# Altium schematic sheet SH02 - MCU & IOs.SchDoc
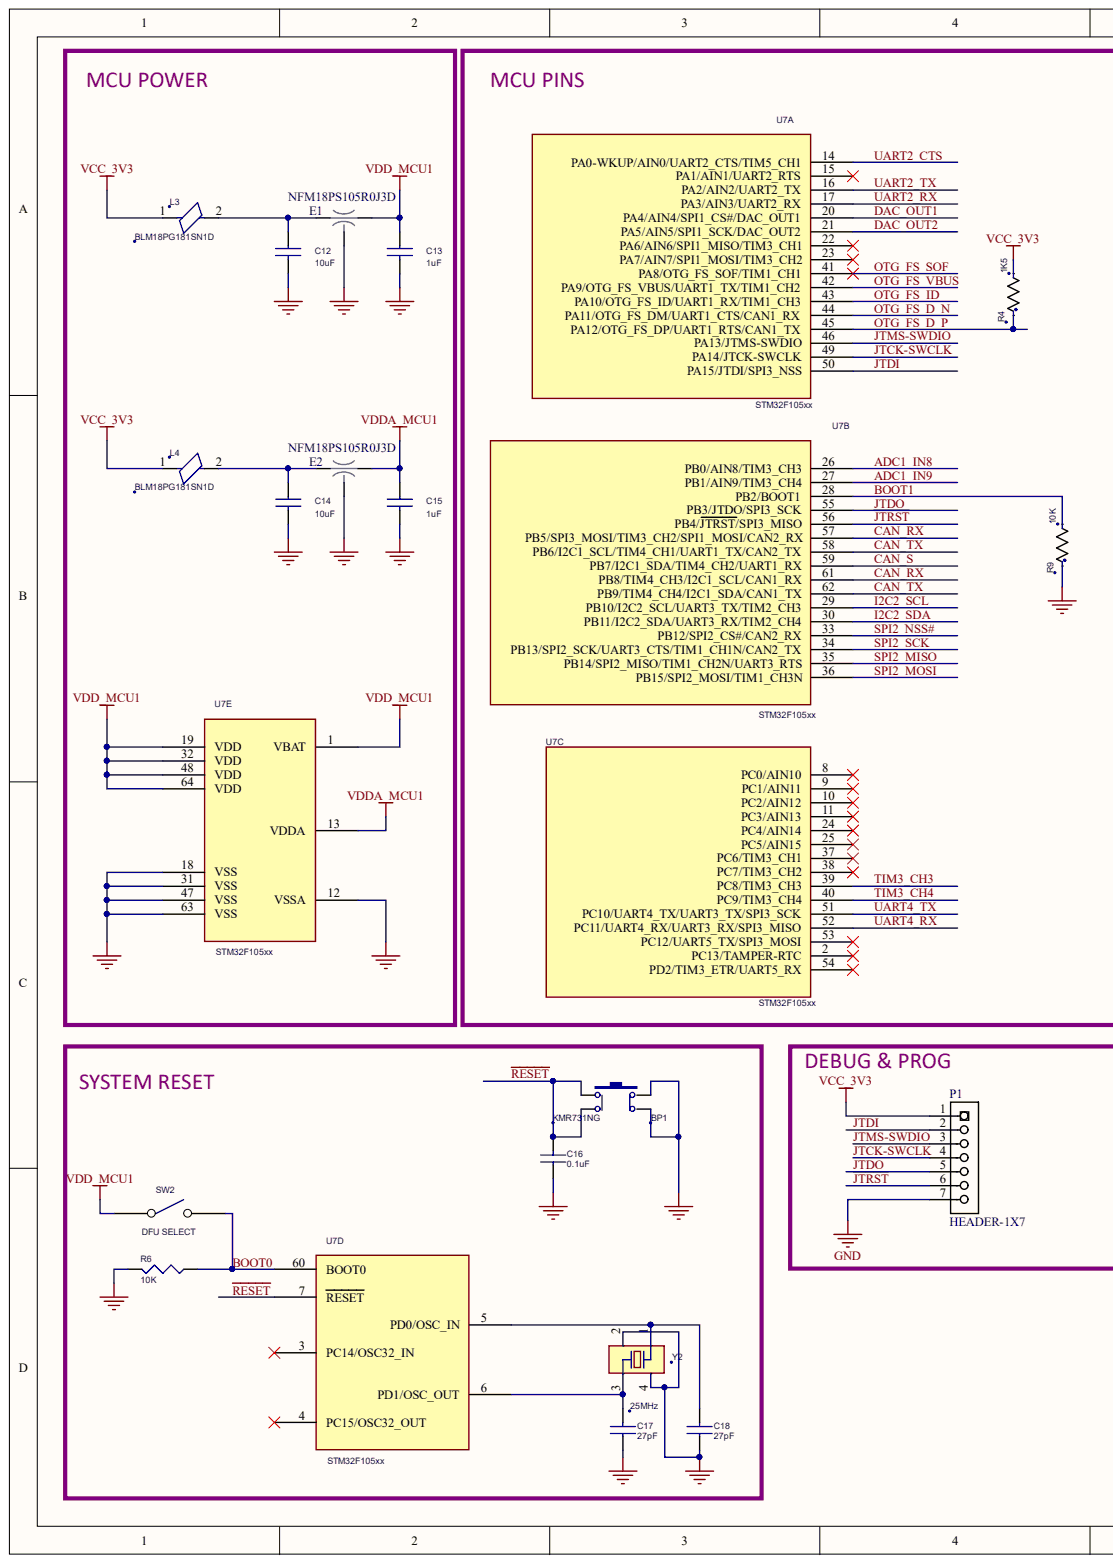
[diagram p0: SH02 - MCU & IOs.SchDoc, left]
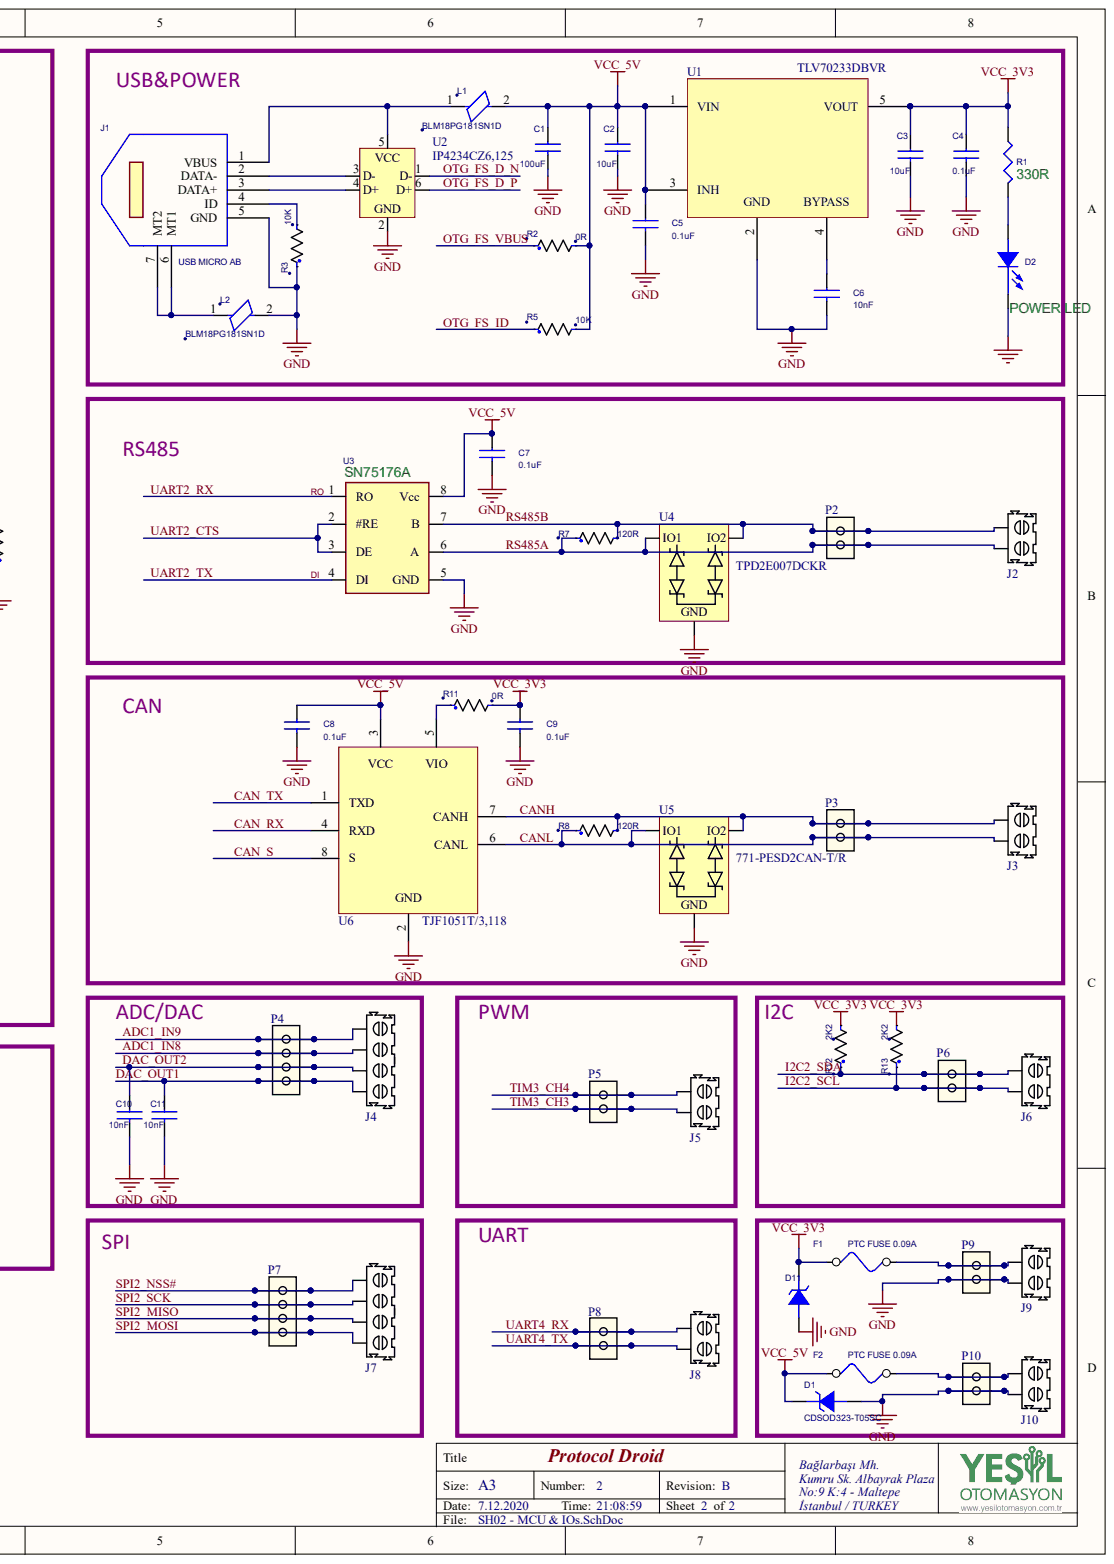
[diagram p1: SH02 - MCU & IOs.SchDoc, right]

## Parts
BP1  KMR731NG  [KMR7]
C1  100uF  [SM/C_1210]
C2  10uF  [RC0603]
C3  10uF  [RC0603]
C4  0.1uF  [RC0603]
C5  0.1uF  [RC0603]
C6  10nF  [RC0603]
C7  0.1uF  [RC0603]
C8  0.1uF  [RC0603]
C9  0.1uF  [RC0603]
C10  10nF  [RC0603]
C11  10nF  [RC0603]
C12  10uF  [RC0603]
C13  1uF  [RC0603]
C14  10uF  [RC0603]
C15  1uF  [RC0603]
C16  0.1uF  [RC0603]
C17  27pF  [RC0603]
C18  27pF  [RC0603]
D1  CDSOD323-T05SC  [SOD323]
D2  POWER LED  [SM/D_0805]
D11  SP4020-01FTG-C  [SOD323]  (value not drawn)
E1  NFM18PS105R0J3D  [SM/E_0603-3P]
E2  NFM18PS105R0J3D  [SM/E_0603-3P]
F1  PTC FUSE 0.09A  [SM/C_1210]
F2  PTC FUSE 0.09A  [SM/C_1210]
J1  USB MICRO AB  [USB/MICROAB/FCI_10104111]
J2  1984617  [OSTTE020161]  (value not drawn)
J3  1984617  [OSTTE020161]  (value not drawn)
J4  1984633  [OSTTE040161]  (value not drawn)
J5  1984617  [OSTTE020161]  (value not drawn)
J6  1984617  [OSTTE020161]  (value not drawn)
J7  1984633  [OSTTE040161]  (value not drawn)
J8  1984617  [OSTTE020161]  (value not drawn)
J9  1984617  [OSTTE020161]  (value not drawn)
J10  1984617  [OSTTE020161]  (value not drawn)
L1  BLM18PG181SN1D  [R0603]
L2  BLM18PG181SN1D  [R0603]
L3  BLM18PG181SN1D  [R0603]
L4  BLM18PG181SN1D  [R0603]
P1  HEADER-1X7  [HDR1X7]  (no BOM)
P2  861400021YO2LF  [HEADER_254_FEM_2x1]  (value not drawn)
P3  861400021YO2LF  [HEADER_254_FEM_2x1]  (value not drawn)
P4  861400041YO2LF  [HEADER_254_FEM_4x1]  (value not drawn)
P5  861400021YO2LF  [HEADER_254_FEM_2x1]  (value not drawn)
P6  861400021YO2LF  [HEADER_254_FEM_2x1]  (value not drawn)
P7  861400041YO2LF  [HEADER_254_FEM_4x1]  (value not drawn)
P8  861400021YO2LF  [HEADER_254_FEM_2x1]  (value not drawn)
P9  861400021YO2LF  [HEADER_254_FEM_2x1]  (value not drawn)
P10  861400021YO2LF  [HEADER_254_FEM_2x1]  (value not drawn)
R1  330R  [R0603]
R2  0R  [R0603]
R3  10K  [R0603]
R4  1K5  [R0603]
R5  10K  [R0603]
R6  10K  [R0603]
R7  120R  [R0603]
R8  120R  [R0603]
R9  10K  [R0603]
R11  0R  [R0603]
R12  2K2  [R0603]
R13  2K2  [R0603]
SW2  DFU SELECT  [HEADER_2_2.54MM]  (no BOM)
U1  TLV70233DBVR  [SOT-23-5]
U2  IP4234CZ6,125  [SOT457]
U3  SN75176A  [8-SOIC]
U4  TPD2E007DCKR  [R-PDSO-G3]
U5  771-PESD2CAN-T/R  [SOT-23]
U6  TJF1051T/3,118  [8-SOIC]
U7  STM32F105xx  [SM/LQFP64/0.5MM]  (parts A,B,C,D,E)
Y2  25MHz  [SM/XTAL_3.2x2.5]

## Nets
GND: BP1.2 BP1.4 C1.2 C2.2 C3.2 C4.2 C5.2 C6.2 C7.2 C8.2 C9.2 C10.2 C11.2 C12.2 C13.2 C14.2 C15.2 C16.1 C17.1 C18.1 D1.A D2.2 D11.A E1.3 E2.3 J1.5(GND) J9.2 J10.2 L2.2 P1.7 P9.2 P10.2 R3.1 R6.2 R9.1 U1.2 U2.2 U3.5(GND) U4.3 U5.3 U6.2 U7.12(VSSA) U7.18(VSS) U7.31(VSS) U7.47(VSS) U7.63(VSS) Y2.2 Y2.4
VCC_3V3: C3.1 C4.1 C9.1 D11.K F1.1 L3.1 L4.1 P1.1 R1.1 R4.2 R11.2 R12.2 R13.2 U1.5(VOUT)
VCC_5V: C1.1 C2.1 C5.1 C7.1 C8.1 D1.K F2.1 L1.2 R2.2 R5.2 U1.1(VIN) U1.3(INH) U3.8(Vcc) U6.3
VDD_MCU1: C13.1 E1.2 SW2.1 U7.1(VBAT) U7.19(VDD) U7.32(VDD) U7.48(VDD) U7.64(VDD)
VDDA_MCU1: C15.1 E2.2 U7.13(VDDA)
ADC1_IN8: J4.2 P4.2 U7.26(PB0/AIN8/TIM3_CH3)
ADC1_IN9: J4.1 P4.1 U7.27(PB1/AIN9/TIM3_CH4)
BOOT0: R6.1 SW2.2 U7.60(BOOT0)
BOOT1: R9.2 U7.28(PB2/BOOT1)
CAN_RX: U6.4(RXD) U7.57(PB5/SPI3_MOSI/TIM3_CH2/SPI1_MOSI/CAN2_RX) U7.61(PB8/TIM4_CH3/I2C1_SCL/CAN1_RX)
CAN_S: U6.8(S) U7.59(PB7/I2C1_SDA/TIM4_CH2/UART1_RX)
CAN_TX: U6.1(TXD) U7.58(PB6/I2C1_SCL/TIM4_CH1/UART1_TX/CAN2_TX) U7.62(PB9/TIM4_CH4/I2C1_SDA/CAN1_TX)
CANH: J3.1 P3.1 R8.2 U5.2 U6.7(CANH)
CANL: J3.2 P3.2 R8.1 U5.1 U6.6(CANL)
DAC_OUT1: C11.1 J4.4 P4.4 U7.20(PA4/AIN4/SPI1_CS#/DAC_OUT1)
DAC_OUT2: C10.1 J4.3 P4.3 U7.21(PA5/AIN5/SPI1_SCK/DAC_OUT2)
DI: U3.4(DI) U7.16(PA2/AIN2/UART2_TX)
I2C2_SCL: J6.2 P6.2 R13.1 U7.29(PB10/I2C2_SCL/UART3_TX/TIM2_CH3)
I2C2_SDA: J6.1 P6.1 R12.1 U7.30(PB11/I2C2_SDA/UART3_RX/TIM2_CH4)
~{JTRST}: P1.6 U7.56(PB4/JTRST/SPI3_MISO)
JTCK-SWCLK: P1.4 U7.49(PA14/JTCK-SWCLK)
JTDI: P1.2 U7.50(PA15/JTDI/SPI3_NSS)
JTDO: P1.5 U7.55(PB3/JTDO/SPI3_SCK)
JTMS-SWDIO: P1.3 U7.46(PA13/JTMS-SWDIO)
OTG_FS_D_N: U2.1(D-) U7.44(PA11/OTG_FS_DM/UART1_CTS/CAN1_RX)
OTG_FS_D_P: R4.1 U2.6(D+) U7.45(PA12/OTG_FS_DP/UART1_RTS/CAN1_TX)
OTG_FS_ID: R5.1 U7.43(PA10/OTG_FS_ID/UART1_RX/TIM1_CH3)
OTG_FS_SOF: U7.41(PA8/OTG_FS_SOF/TIM1_CH1)
OTG_FS_VBUS: R2.1 U7.42(PA9/OTG_FS_VBUS/UART1_TX/TIM1_CH2)
~{RESET}: BP1.1 BP1.3 C16.2 U7.7(RESET)
RO: U3.1(RO) U7.17(PA3/AIN3/UART2_RX)
RS485A: J2.2 P2.2 R7.1 U3.6(A) U4.1
RS485B: J2.1 P2.1 R7.2 U3.7(B) U4.2
SPI2_MISO: J7.3 P7.3 U7.35(PB14/SPI2_MISO/TIM1_CH2N/UART3_RTS)
SPI2_MOSI: J7.4 P7.4 U7.36(PB15/SPI2_MOSI/TIM1_CH3N)
SPI2_NSS#: J7.1 P7.1 U7.33(PB12/SPI2_CS#/CAN2_RX)
SPI2_SCK: J7.2 P7.2 U7.34(PB13/SPI2_SCK/UART3_CTS/TIM1_CH1N/CAN2_TX)
TIM3_CH3: J5.2 P5.2 U7.39(PC8/TIM3_CH3)
TIM3_CH4: J5.1 P5.1 U7.40(PC9/TIM3_CH4)
UART2_CTS: U3.2(#RE) U3.3(DE) U7.14(PA0-WKUP/AIN0/UART2_CTS/TIM5_CH1)
UART4_RX: J8.1 P8.1 U7.52(PC11/UART4_RX/UART3_RX/SPI3_MISO)
UART4_TX: J8.2 P8.2 U7.51(PC10/UART4_TX/UART3_TX/SPI3_SCK)
NetC6_1: C6.1 U1.4
NetC12_1: C12.1 E1.1 L3.2
NetC14_1: C14.1 E2.1 L4.2
NetC17_2: C17.2 U7.6(PD1/OSC_OUT) Y2.3
NetC18_2: C18.2 U7.5(PD0/OSC_IN) Y2.1
NetD2_1: D2.1 R1.2
NetF1_2: F1.2 J9.1 P9.1
NetF2_2: F2.2 J10.1 P10.1
NetJ1_1: J1.1(VBUS) L1.1 U2.5
NetJ1_2: J1.2(DATA-) U2.3(D-)
NetJ1_3: J1.3(DATA+) U2.4(D+)
NetJ1_4: J1.4(ID) R3.2
NetJ1_6: J1.6(MT1) J1.7(MT2) L2.1
NetR11_1: R11.1 U6.5

Not connected: U7 2(PC13/TAMPER-RTC) 3(PC14/OSC32_IN) 4(PC15/OSC32_OUT) 8(PC0/AIN10) 9(PC1/AIN11) 10(PC2/AIN12) 11(PC3/AIN13) 15(PA1/AIN1/UART2_RTS) 22(PA6/AIN6/SPI1_MISO/TIM3_CH1) 23(PA7/AIN7/SPI1_MOSI/TIM3_CH2) 24(PC4/AIN14) 25(PC5/AIN15) 37(PC6/TIM3_CH1) 38(PC7/TIM3_CH2) 53(PC12/UART5_TX/SPI3_MOSI) 54(PD2/TIM3_ETR/UART5_RX)

## Library data of the parts
BP1 = switch2_0: SWITCH TACTILE SPST-NO 50MA 32V; Manufacturer: ITT C&K; Manufacturer Part Number: KMR731NGLFS; Actuator Height off PCB, Vertical: 2.50mm; Actuator Orientation: Top Actuated; Actuator Type: Standard; Categories: Switches; Contact Rating @ Voltage: 0.05A @ 32VDC; Illumination: Non-Illuminated; Ingress Protection: IP67 - Dust Tight, Waterproof; Mechanical Life: 150,000 Cycles; Operating Force: 300gf; Outline: 4.20mm x 2.80mm; Switch Function: Off-Mom; Actuator Length, Right Angle: -; Actuator Material: -; Circuit: SPST-NO; Component Kind: Standard; ComponentLink1Description: https://www.ckswitches.com/media/1908/kmr7.pdf?src-supplier=Digi-Key; ComponentLink1URL: https://www.ckswitches.com/media/1908/kmr7.pdf?src-supplier=Digi-Key; ComponentLink2Description: http://www.farnell.com/datasheets/2578460.pdf?src-supplier=Farnell; ComponentLink2URL: http://www.farnell.com/datasheets/2578460.pdf?src-supplier=Farnell; Features: -; Illumination Type, Color: -; Illumination Voltage (Nominal): -; Mounting Type: Surface Mount; Operating Temperature: -40°C ~ 85°C; Packaging: Tape & Reel (TR); Part Status: Active; Pricing 1: 1=0.34, 10=0.333, 25=0.3208, 50=0.309, 100=0.2972, 250=0.2734, 500=0.2378, 1000=0.2021 (USD); Series: KMR7; Stock 1: 5807; Supplier 1: Digi-Key; Supplier Part Number 1: CKN10687CT-ND; Switch Travel: -; Termination Style: Gull Wing
C1 = CAP NP: CAP,CER SMT 1210 100uF 6V3 20% X7S; Manufacturer: Murata; Manufacturer Part Number: GRM32EC70J107ME15L; Capacitance: 100µF; COMPFIXED: NO; COMPGROUP: 0; COMPLOC: [814.000,-1923.367]; COMPLOCKED: YES; COMPSIDE: TOP; Unit Cost 1k: 0,39; Voltage - Rated: 6.3V; Applications: General Purpose; Category: Capacitors; COMPHEIGHT: 0; COMPKEY: NO; Component Kind: Standard; ComponentLink1Description: http://search.murata.co.jp/Ceramy/image/img/A01X/G101/ENG/GRM32EC70J107ME15-01.pdf?src-supplier=Digi-Key; ComponentLink1URL: http://search.murata.co.jp/Ceramy/image/img/A01X/G101/ENG/GRM32EC70J107ME15-01.pdf?src-supplier=Digi-Key; ComponentLink2Description: http://www.produktinfo.conrad.com/datenblaetter/1300000-1399999/001316735-da-01-en-MLCC_1210_100_0_UF_6_3V_X7S__20__1000ST.pdf?src-supplier=Conrad; ComponentLink2URL: http://www.produktinfo.conrad.com/datenblaetter/1300000-1399999/001316735-da-01-en-MLCC_1210_100_0_UF_6_3V_X7S__20__1000ST.pdf?src-supplier=Conrad; COMPROT: 0; DECOUPLER: NO; Family: Ceramic Capacitors; Features: Derating Recommended; Height - Seated (Max): -; Lead Spacing: -; Lead Style: -; MEMORY: NO; Mounting Type: Surface Mount, MLCC; Operating Temperature: -55°C ~ 125°C; Package / Case: 1210 (3225 Metric); Packaging: Tape & Reel (TR); Pricing 1: 1=0.79, 10=0.582, 50=0.4658, 100=0.3993, 500=0.3161 (USD); Ratings: -; Series: GRM; Size / Dimension: 0.126" L x 0.098" W (3.20mm x 2.50mm); Stock 1: 52391; Supplier 1: Digi-Key; Supplier Part Number 1: 490-10530-6-ND; Temperature Coefficient: X7S; Thickness (Max): 0.106" (2.70mm); Tolerance: ±20%
C2 C3 C12 C14 = CAP NP: CAP,CER SMT 0603 10uF 6V3 10% X5R; Manufacturer: Murata Electronics; Manufacturer Part Number: GRM188R60J106ME84J; Capacitance: 10µF; Catalog Drawings: GRM Series_0603_0.8; COMPFIXED: NO; COMPGROUP: 0; COMPHEIGHT: 0.025; COMPLOC: [54.600,29.800]; COMPROT: 270; COMPSIDE: BOT; Dynamic Catalog: GRM Series; Other Names: 490-7317-2; Tolerance: ±20%; Unit Cost 1k: 0,011; Unit PriceUSD: GRM; Voltage - Rated: 6.3V; Applications: General Purpose; Category: Capacitors; COMPKEY: NO; COMPLOCKED: NO; Component Kind: Standard; ComponentLink1Description: https://www.murata.com/~/media/webrenewal/support/library/catalog/products/capacitor/mlcc/c02e.ashx?la=en-us&src-supplier=Digi-Key; ComponentLink1URL: https://www.murata.com/~/media/webrenewal/support/library/catalog/products/capacitor/mlcc/c02e.ashx?la=en-us&src-supplier=Digi-Key; DECOUPLER: NO; Family: Ceramic Capacitors; MEMORY: NO; Minimum Quantity: 10µF; Mounting Type: Surface Mount, MLCC; Online Catalog: GRM Series; Operating Temperature: -55°C ~ 85°C; Package / Case: 0603 (1608 Metric); Package/Case: 0603 (1608 Metric); Packaging: Tape & Reel (TR); Pricing 1: 10000=0.0744, 20000=0.0721 (USD); Quantity Available: Tape & Reel (TR); Series: GRM; Size / Dimension: 0.063" L x 0.031" W (1.60mm x 0.80mm); Standard Package: 10,000; Stock 1: 30000; Supplier 1: Digi-Key; Temperature Coefficient: X5R; Thickness (Max): 0.035" (0.90mm)
C4 C5 C7-C9 C16 = CAP NP: CAP,CER SMT 0603 0.1uF 10V 10% X5R; Manufacturer Part Number: CC0603KRX7R6BB104; Brand: Yageo; COMPFIXED: NO; COMPGROUP: 0; COMPHEIGHT: 0.025; COMPLOC: [28.200,53.900]; COMPROT: 270; COMPSIDE: BOT; Dielectric: X7R; Type: Multilayer Ceramic Chip Capacitor; Unit Cost 1k: 0,00165; Unit Weight: 0.000071 oz; Voltage Rating DC: 10 VDC; Capacitance: 0.1 uF; Case Code - in: 0603; Case Code - mm: 1608; COMPKEY: NO; COMPLOCKED: NO; Component Kind: Standard; ComponentLink1Description: http://www.yageo.com/documents/recent/UPY-GPHC_X7R_6.3V-to-50V_8.pdf?src-supplier=Avnet; ComponentLink1URL: http://www.yageo.com/documents/recent/UPY-GPHC_X7R_6.3V-to-50V_8.pdf?src-supplier=Avnet; ComponentLink2Description: http://www.yageo.com/documents/recent/UPY-GPHC_X7R_6.3V-to-50V_8.pdf?src-supplier=Avnet+Europe; ComponentLink2URL: http://www.yageo.com/documents/recent/UPY-GPHC_X7R_6.3V-to-50V_8.pdf?src-supplier=Avnet+Europe; DECOUPLER: NO; Length: 1.6 mm; Manufacturer: Yageo; Maximum Operating Temperature: + 125 C; MEMORY: NO; Minimum Operating Temperature: - 55 C; Package / Case: 0603 (1608 metric); Packaging: Reel; Pricing 1: 1=0.12, 10=0.06, 100=0.027, 1000=0.022, 4000=0.016, 8000=0.016 (USD); Product: General Type MLCCs; Product Category: Multilayer Ceramic Capacitors MLCC - SMD/SMT; RoHS: True; Stock 1: 5586; Supplier 1: Mouser; Termination Style: SMD/SMT; Tolerance: 10 %; Width: 0.8 mm
C6 C10 C11 = CAP NP: CAP,CER SMT 0603 10nF 10V 10% X5R; Manufacturer Part Number: C0603C103K8RACTU; Part # Aliases: C0603C103K8RAC7867; Brand: Kemet; Capacitance: 0.01 uF; Capacitance - pF: 10000 pF; Case Height: 0.8 mm; Case Length: 1.6 mm; Class: Class 1; COMPFIXED: NO; COMPGROUP: 0; COMPHEIGHT: 0.025; COMPLOC: [28.200,53.900]; COMPROT: 270; COMPSIDE: BOT; Dielectric: X7R; Dissipation Factor DF: 5; Temperature Coefficient / Code: +/- 15 %; Type: SMD MLCC X7R 6.3 - 250 VDC Commerical Grade; Unit Cost 1k: 0,00165; Capacitance - nF: 10 nF; Case Code - in: 0603; Case Code - mm: 1608; Case Width: 0.8 mm; COMPKEY: NO; COMPLOCKED: NO; Component Kind: Standard; ComponentLink1Description: http://www.jameco.com/Jameco/Products/ProdDS/1564916.pdf?src-supplier=Jameco; ComponentLink1URL: http://www.jameco.com/Jameco/Products/ProdDS/1564916.pdf?src-supplier=Jameco; DECOUPLER: NO; Dimensions: 0.8 mm W x 1.6 mm L x 0.8 mm H; Factory Pack Quantity: 4000; Lead Spacing: 0.7 mm; Manufacturer: KEMET; Maximum Operating Temperature: + 125 C; MEMORY: NO; Minimum Operating Temperature: - 55 C; Operating Temperature Range: - 55 C to + 125 C; Package / Case: 0603 (1608 metric); Packaging: Reel; Pricing 1: 1=0.1, 10=0.068, 50=0.037, 100=0.0302, 500=0.0216, 1000=0.0169 (USD); Product: General Type MLCCs; Product Category: Multilayer Ceramic Capacitors MLCC - SMD/SMT; RoHS: Details; Series: C0603C; Stock 1: 33298; Supplier 1: Digi-Key; Termination Style: SMD/SMT; Tolerance: 10 %; Voltage Rating: 10 V; Voltage Rating DC: 10 V
C13 C15 = CAP NP: CAP,CER SMT 0603 1uF 10V 10% X5R; Manufacturer: Samsung; Manufacturer Part Number: CL10A105KP8NNNC; Capacitance: 1000000 pF; COMPFIXED: NO; COMPGROUP: 0; COMPHEIGHT: 0.025; COMPLOC: [53.300,14.900]; COMPROT: 90; COMPSIDE: BOT; Unit Cost 1k: 0,00245; Case Size/Dimension: 0603; COMPKEY: NO; COMPLOCKED: NO; Component Kind: Standard; ComponentLink1Description: https://www.verical.com/server-webapp/html/getDatasheet?partID=358616&src-supplier=Verical; ComponentLink1URL: https://www.verical.com/server-webapp/html/getDatasheet?partID=358616&src-supplier=Verical; ComponentLink2Description: http://www1.futureelectronics.com/doc/SAMSUNG%20PASSIVE/CL05B104KQ5NNNC.pdf?src-supplier=Future+Electronics; ComponentLink2URL: http://www1.futureelectronics.com/doc/SAMSUNG%20PASSIVE/CL05B104KQ5NNNC.pdf?src-supplier=Future+Electronics; DECOUPLER: NO; Dielectric: X5R; MEMORY: NO; Pricing 1: 1=0.1, 10=0.038, 50=0.0208, 100=0.017, 500=0.0121, 1000=0.0095 (USD); Rated Voltage: 10 V; Stock 1: 655615; Supplier 1: Digi-Key; Supplier Part Number 1: 1276-1182-6-ND; Tolerance (%): ±10 %
C17 C18 = CAP NP: CAP,CER SMT 0603 27pF 50V 10% X5R; Manufacturer: Yageo; Manufacturer Part Number: CC0603JRNPO9BN270; COMPFIXED: NO; COMPGROUP: 0; COMPHEIGHT: 0.025; COMPLOC: [28.200,53.900]; COMPROT: 270; COMPSIDE: BOT; Featured Product: Commodity Multilayer Ceramic Capacitors – CC Series; Online Catalog: CC Series; Other Names: 311-1063-6 311-1281-6 311-1281-6-ND; Product Training Modules: Multilayer Ceramic Capacitors; Temperature Coefficient: C0G, NP0; Tolerance: ±5%; Unit Cost 1k: 0,00165; Applications: General Purpose; Capacitance: 27pF; Category: Capacitors; COMPKEY: NO; COMPLOCKED: NO; Component Kind: Standard; ComponentLink1Description: http://www.yageo.com/documents/recent/UPY-GP_NP0_16V-to-50V_16.pdf?src-supplier=Digi-Key; ComponentLink1URL: http://www.yageo.com/documents/recent/UPY-GP_NP0_16V-to-50V_16.pdf?src-supplier=Digi-Key; ComponentLink2Description: http://www.yageo.com/documents/recent/UPY-GP_NP0_16V-to-50V_8.pdf?src-supplier=Avnet; ComponentLink2URL: http://www.yageo.com/documents/recent/UPY-GP_NP0_16V-to-50V_8.pdf?src-supplier=Avnet; ComponentLink3Description: http://www.farnell.com/datasheets/2213336.pdf?src-supplier=Element14; ComponentLink3URL: http://www.farnell.com/datasheets/2213336.pdf?src-supplier=Element14; ComponentLink4Description: https://www.verical.com/server-webapp/html/getDatasheet?partID=403529&src-supplier=Verical; ComponentLink4URL: https://www.verical.com/server-webapp/html/getDatasheet?partID=403529&src-supplier=Verical; ComponentLink5Description: http://www.farnell.com/datasheets/1443161.pdf?src-supplier=Element14; ComponentLink5URL: http://www.farnell.com/datasheets/1443161.pdf?src-supplier=Element14; ComponentLink6Description: http://www.yageo.com/documents/recent/UPY-GP_NP0_16V-to-50V_8.pdf?src-supplier=Avnet+Europe; ComponentLink6URL: http://www.yageo.com/documents/recent/UPY-GP_NP0_16V-to-50V_8.pdf?src-supplier=Avnet+Europe; DECOUPLER: NO; Family: Ceramic Capacitors; Features: -; Height - Seated (Max): -; Lead Spacing: -; Lead Style: -; MEMORY: NO; Mounting Type: Surface Mount, MLCC; Operating Temperature: -55°C ~ 125°C; Package / Case: 0603 (1608 Metric); Packaging: Digi-Reel®; Pricing 1: 4000=0.0079, 8000=0.0072, 12000=0.0069 (USD); Ratings: -; Series: CC; Size / Dimension: 0.063" L x 0.031" W (1.60mm x 0.80mm); Standard Package: 1; Stock 1: 120000; Supplier 1: Digi-Key; Supplier Part Number 1: 311-1063-2-ND; Thickness (Max): 0.035" (0.90mm); Voltage - Rated: 50V
D1 = DIODE ZENER: TVS, DIODE 5V 13.5V SOD323; Manufacturer: Bourns Inc.; Capacitance @ Frequency: 350pF @ 1MHz; Current - Peak Pulse (10/1000µs): 42A (8/20µs); Power - Peak Pulse: 500W; Power Line Protection: No; Type: Zener; Voltage - Breakdown (Min): 6V; Voltage - Clamping (Max) @ Ipp: 13.5V (Typ); Applications: General Purpose; Category: Circuit Protection; Component Kind: Standard; ComponentLink1Description: http://www.bourns.com/docs/Product-Datasheets/CDSOD323-TxxSC.pdf; ComponentLink1URL: http://www.bourns.com/docs/Product-Datasheets/CDSOD323-TxxSC.pdf; Manufacturer Part Number: CDSOD323-T05SC; Mounting Type: Surface Mount; Operating Temperature: -55°C ~ 150°C (TJ); Package / Case: SC-76, SOD-323; Packaging: Cut Tape (CT); Part Status: Active; Pricing 1: 1=0.72, 10=0.606, 25=0.53, 100=0.4542, 250=0.39368, 500=0.3331, 1000=0.2574 (USD); RoHS: RoHS Compliant; Stock 1: 103990; Supplier 1: Digi-Key; Supplier Device Package: SOD-323; Supplier Part Number 1: CDSOD323-T05SCT-ND; Voltage - Reverse Standoff (Typ): 5V
D2 = LED: LED,SMT 0805 GREEN CLEAR; Manufacturer: Osram Opto; Manufacturer Part Number: LGR971-KN-1; Chip Material: GaP; DELETED: Compliant; Dominant Wave Length: 570 nm; Emitting Color: Yellow Green; Forward Current: 25 mA; Forward Voltage: 2.5 V; High Brightness: No; Lens Type: Colorless Diffused; Luminous Flux: 0.1lm; Luminous Intensity: 45mcd; Main Category: Chip LED; Number of  Elements: 1; Peak Wavelength: 572 nm; Power Dissipation: 65 W; Product Depth (mm): 1.35 mm; Product Height (mm): 0.9 mm; Product Length (mm): 2.1 mm; Reverse Voltage: 12 V; Shape Type: Rectangular; Test Current (It): 20 mA; Type: Uni-Color; Viewing Angle: 160 deg; Component Kind: Standard; ComponentLink1Description: https://www.verical.com/server-webapp/html/getDatasheet?partID=233880&src-supplier=Verical; ComponentLink1URL: https://www.verical.com/server-webapp/html/getDatasheet?partID=233880&src-supplier=Verical; ComponentLink2Description: https://www.verical.com/server-webapp/html/getDatasheet?partID=462214&src-supplier=Verical; ComponentLink2URL: https://www.verical.com/server-webapp/html/getDatasheet?partID=462214&src-supplier=Verical; ComponentLink3Description: https://dammedia.osram.info/media/resource/hires/osram-dam-2493936/LG%20R971.pdf?src-supplier=Digi-Key; ComponentLink3URL: https://dammedia.osram.info/media/resource/hires/osram-dam-2493936/LG%20R971.pdf?src-supplier=Digi-Key; ComponentLink4Description: http://www.mouser.com/ds/2/311/LG%20R971%20-%20CHIPLED%200805-318376.pdf?src-supplier=Mouser; ComponentLink4URL: http://www.mouser.com/ds/2/311/LG%20R971%20-%20CHIPLED%200805-318376.pdf?src-supplier=Mouser; Lens Dimensions: 1.4x1.35x0.5 mm; Lum Intensity: 45mcd; Mounting: Surface Mount; Operating Temperature Classification: Commercial; Operating Temp Range: -30C to 85C; Package Type: SMT; Packaging: Tape and Reel; Pin Count: 2; Pricing 1: 1=0.25, 10=0.186, 100=0.0882, 500=0.0588, 1000=0.046 (USD); Rad Hardened: No; Stock 1: 241820; Supplier 1: Digi-Key; Supplier Part Number 1: 475-1410-1-ND
D11 = DIODE ZENER: TVS,Diode Arrays 2.5pF 30A; Brand: Littelfuse; Breakdown Voltage: 3.5 V; Clamping Voltage: 21.8 V; Pd - Power Dissipation: 750 W; Polarity: Bidirectional; Working Voltage: 3.3 V; Component Kind: Standard; Factory Pack Quantity: 3000; Height: 1 mm; Length: 2.7 mm; Manufacturer: Littelfuse; Manufacturer Part Number: SP4020-01FTG-C; Maximum Operating Temperature: + 125 C; Minimum Operating Temperature: - 40 C; Mounting Style: SMD/SMT; Package / Case: SOD-323; Packaging: Reel; Peak Surge Current: 30 A; Pricing 1: 1=0.72, 10=0.606, 25=0.53, 100=0.4542, 250=0.3937, 500=0.3331, 1000=0.2574 (USD); Product Category: TVS Diode Arrays; RoHS: Details; Series: SP4020; Stock 1: 156787; Supplier 1: Digi-Key; Termination Style: SMD/SMT; Tradename: SPA; Width: 1.4 mm
E1 E2 = SM/0603-3P: CAP,FEEDTHRU 1UF 20% 6.3V 0603; Manufacturer: Murata Electronics; DC Resistance (DCR) (Max): 30 mOhm; Category: Filters; Component Kind: Standard; ComponentLink1Description: http://search.murata.co.jp/Ceramy/image/img/A01X/G101/ENG/NFM18PS105R0J3-01.pdf; ComponentLink1URL: http://search.murata.co.jp/Ceramy/image/img/A01X/G101/ENG/NFM18PS105R0J3-01.pdf; Height (Max): 0.028" (0.70mm); Insertion Loss: -; Manufacturer Part Number: NFM18PS105R0J3D; Mounting Type: Surface Mount; Operating Temperature: -55°C ~ 105°C; Package / Case: 0603 (1608 Metric), 3 PC Pad; Packaging: Cut Tape (CT); Part Status: Active; Pricing 1: 1=0.16, 10=0.152, 25=0.1448, 50=0.1402, 100=0.1356, 250=0.12856, 500=0.10752, 1000=0.08345 (USD); Ratings: -; RoHS: RoHS Compliant; Size / Dimension: 0.063" L x 0.032" W (1.60mm x 0.80mm); Stock 1: 444667; Supplier 1: Digi-Key; Supplier Part Number 1: 490-6974-1-ND; Temperature Coefficient: -; Thread Size: -; Tolerance: ±20%; Voltage - Rated: 6.3V; Library Name: SSTE.SCHLIB
F1 F2 = FUSE: PTC RESET FUSE 30V 90MA 1210; Manufacturer: EPCOS (TDK); Manufacturer Part Number: B59606A0110A062; Approvals: UR; Type: Ceramic; Category: Circuit Protection; Component Kind: Standard; ComponentLink1Description: https://en.tdk.eu/inf/55/db/PTC/PTC_OC_SMD_0402_0603_1210_24V_230V.pdf; ComponentLink1URL: https://en.tdk.eu/inf/55/db/PTC/PTC_OC_SMD_0402_0603_1210_24V_230V.pdf; Height - Seated (Max): -; Lead Spacing: -; Mounting Type: Surface Mount; Operating Temperature: -20°C ~ 85°C; Package / Case: 1210 (3225 Metric); Packaging: Tape & Reel (TR); Part Status: Active; Pricing 1: 2000=0.46682, 4000=0.45833, 6000=0.44135, 10000=0.42438 (USD); Ratings: AEC-Q200; RoHS: RoHS Compliant; Size / Dimension: 0.126" L x 0.098" W (3.20mm x 2.50mm); Stock 1: 6000; Supplier 1: Digi-Key; Supplier Part Number 1: 495-2416-2-ND; Thickness (Max): 0.071" (1.80mm); Voltage - Max: 30V
J1 = USB MICRO AB_0: CONN,SMD USB MICRO AB RECEPTACLE; Manufacturer: Amphenol FCI; Manufacturer Part Number: 10104111-0001LF; Unit Cost 1k: 0,37; Component Kind: Standard
J2 J3 J5 J6 J8-J10 = OSTTE020161: TERM,BLK 2POS SIDE ENT 3.5MM PCB; Manufacturer: Phoenix Contact; Actuation direction: Perpendicular (to the PCB); AWG (min.): 26; AWG max.: 16; Connection: Screw terminal, Print; Connector orientation: Parallel (to the PCB); Content: 250 pc(s); Cross section (flexible) (max.): 1.50 mm²; Cross section (flexible) (min.): 0.20 mm²; CS: 3.50 mm; Industrial packaging: Yes; Nominal current: 17.5 A; Nominal voltage: 200 V; Pins per row: 2; Stripping length (max.): 5.00 mm; Category: Screw terminal; Colour: Green; Component Kind: Standard; ComponentLink1Description: http://components.arrow.com/part/dataview/43944892S4798812N1555?src-supplier=Arrow+Electronics; ComponentLink1URL: http://components.arrow.com/part/dataview/43944892S4798812N1555?src-supplier=Arrow+Electronics; ComponentLink2Description: https://media.digikey.com/pdf/Data%20Sheets/Phoenix%20Contact%20PDFs/Combicon%20Compact%20Series.pdf?src-supplier=Digi-Key; ComponentLink2URL: https://media.digikey.com/pdf/Data%20Sheets/Phoenix%20Contact%20PDFs/Combicon%20Compact%20Series.pdf?src-supplier=Digi-Key; ComponentLink3Description: https://www.verical.com/server-webapp/html/getDatasheet?partID=212214&src-supplier=Verical; ComponentLink3URL: https://www.verical.com/server-webapp/html/getDatasheet?partID=212214&src-supplier=Verical; Contact material: Tin plated; Cross section (inflexible) (max.): 1.50 mm²; Cross section (inflexible) (min.): 0.20 mm²; Features: + wire protector; Height: 7.60 mm; Length: 7.00 mm; Manufacturer part #: 1984617; Manufacturer Part Number: 1984617; Number of pins: 2; Pricing 1: 1=0.48, 10=0.459, 50=0.439, 100=0.4269, 250=0.399, 500=0.3671, 1000=0.3392, 2500=0.3391 (USD); Series: PT; Stock 1: 10306; Supplier 1: Digi-Key; Type: PT 1,5/ 2-3,5-H; Width: 13.70 mm; Wire protection: Yes; Library Name: SSTE.SCHLIB
J4 J7 = OSTTE040161: TERM,BLK 4POS SIDE ENT 3.5MM PCB; Manufacturer: Phoenix Contact; Actuation direction: Perpendicular (to the PCB); AWG (min.): 26; AWG max.: 16; Connection: Screw terminal, Print; Connector orientation: Parallel (to the PCB); Content: 250 pc(s); Cross section (flexible) (max.): 1.50 mm²; Cross section (flexible) (min.): 0.20 mm²; Cross section (inflexible) (min.): 0.14 mm²; CS: 3.50 mm; Industrial packaging: Yes; Nominal current: 17.5 A; Nominal voltage: 200 V; Pins per row: 4; Stripping length (max.): 5.00 mm; Category: Screw terminal; Colour: Green; Component Kind: Standard; ComponentLink1Description: https://media.digikey.com/pdf/Data%20Sheets/Phoenix%20Contact%20PDFs/Combicon%20Compact%20Series.pdf?src-supplier=Digi-Key; ComponentLink1URL: https://media.digikey.com/pdf/Data%20Sheets/Phoenix%20Contact%20PDFs/Combicon%20Compact%20Series.pdf?src-supplier=Digi-Key; Contact material: Tin plated; Cross section (inflexible) (max.): 1.50 mm²; Features: + wire protector; Height: 13.70 mm; Length: 7.60 mm; Manufacturer part #: 1984633; Manufacturer Part Number: 1984633; Number of pins: 4; Pricing 1: 1=0.95, 10=0.906, 50=0.8662, 100=0.8426, 250=0.7875, 500=0.7245, 1000=0.6694 (USD); Series: PT; Stock 1: 8573; Supplier 1: Digi-Key; Supplier Part Number 1: 277-1860-ND; Type: PT 1,5/ 4-3,5-H; Width: 14.00 mm; Wire protection: Yes; Library Name: SSTE.SCHLIB
L1-L4 = BEAD_ FERRITE: FERRITE BEAD, 180 OHM@100 MHZ 0603 1LN; Manufacturer: Murata; Component Kind: Standard; DESIG: Ferrite Bead; Manufacturer Part Number: BLM18PG181SN1D
P2 P3 P5 P6 P8-P10 = 1x2 Header Female 2.54: CONN,HEADER MALE 2POS .1 SGL TIN; Manufacturer: Amphenol FCI; Brand: FCI; Current Rating: 3 A; Insulation Resistance: 1000 MOhms; Mounting Angle: Straight; Number of Positions: 2; Pitch: 2.54 mm; Voltage Rating: 100 V; Component Kind: Standard; ComponentLink1Description: http://portal.fciconnect.com/Comergent/fci/drawing/c-8614-0089.pdf?src-supplier=Avnet; ComponentLink1URL: http://portal.fciconnect.com/Comergent/fci/drawing/c-8614-0089.pdf?src-supplier=Avnet; ComponentLink2Description: http://www.fciconnect.com/fciredir.htm?partnum=861400021YO2LF&src-supplier=Mouser; ComponentLink2URL: http://www.fciconnect.com/fciredir.htm?partnum=861400021YO2LF&src-supplier=Mouser; Contact Gender: Pin (Male); Contact Material: Copper Alloy; Contact Plating: Gold; Factory Pack Quantity: 2000; Flammability Rating: UL 94; Housing Material: Thermoplastic; Manufacturer Part Number: 861400021YO2LF; Number of Rows: 1; Operating Temperature Range: - 55 C to + 125 C; Packaging: Bulk; Pricing 1: 8000=0.0448 (USD); Product Category: Headers & Wire Housings; Product Type: Headers; RoHS: Details; Series: 8614; Stock 1: 0; Supplier 1: Digi-Key; Termination Post Length: 3mm; Termination Style: Through Hole; Type: Unshrouded; Library Name: SSTE.SCHLIB
P4 P7 = 1x4 Header Female 2.54: CONN,HEADER MALE 4POS .1 SGL TIN; Manufacturer: Amphenol FCI; Brand: FCI; Component Kind: Standard; ComponentLink1Description: http://portal.fciconnect.com/Comergent/fci/drawing/c-8614-0089.pdf?src-supplier=Avnet; ComponentLink1URL: http://portal.fciconnect.com/Comergent/fci/drawing/c-8614-0089.pdf?src-supplier=Avnet; ComponentLink2Description: http://portal.fciconnect.com/Comergent//fci/drawing/c-8614-0089.pdf?src-supplier=Digi-Key; ComponentLink2URL: http://portal.fciconnect.com/Comergent//fci/drawing/c-8614-0089.pdf?src-supplier=Digi-Key; Factory Pack Quantity: 2000; Manufacturer Part Number: 861400041YO2LF; Packaging: Bulk; Pricing 1: 8000=0.0773 (USD); Product Category: Headers & Wire Housings; RoHS: Details; Series: BergStik; Stock 1: 0; Supplier 1: Digi-Key; Supplier Part Number 1: 861400041YO2LF-ND; Library Name: SSTE.SCHLIB
R1 = R_Dup1: RES,SMT 0603 330R 1/10WATT; Manufacturer Part Number: ERJ3EKF3300V; Brand: Panasonic; COMPFIXED: NO; COMPGROUP: 0; COMPLOC: [2004.000,-2464.383]; COMPLOCKED: YES; COMPSIDE: TOP; Power Rating: 100 mW; Qualification: AEC-Q200; Resistance: 330 Ohms; Temperature Coefficient: 100 PPM / C; Tolerance: 1 %; Unit Cost 1k: 0,00052; Unit Weight: 0.000071 oz; Voltage Rating: 75 V; Case Code - in: 0603; Case Code - mm: 1608; COMPHEIGHT: 0; COMPKEY: NO; Component Kind: Standard; ComponentLink1Description: http://www.farnell.com/datasheets/1911175.pdf?src-supplier=Element14; ComponentLink1URL: http://www.farnell.com/datasheets/1911175.pdf?src-supplier=Element14; ComponentLink2Description: https://industrial.panasonic.com/cdbs/www-data/pdf/RDA0000/DMA0000COL11.pdf?src-supplier=Avnet; ComponentLink2URL: https://industrial.panasonic.com/cdbs/www-data/pdf/RDA0000/DMA0000COL11.pdf?src-supplier=Avnet; ComponentLink3Description: http://www.farnell.com/datasheets/426256.pdf?src-supplier=Element14; ComponentLink3URL: http://www.farnell.com/datasheets/426256.pdf?src-supplier=Element14; ComponentLink4Description: http://industrial.panasonic.com/cdbs/www-data/pdf/RDA0000/AOA0000C304.pdf?src-supplier=Element14; ComponentLink4URL: http://industrial.panasonic.com/cdbs/www-data/pdf/RDA0000/AOA0000C304.pdf?src-supplier=Element14; COMPROT: 0; DECOUPLER: NO; Factory Pack Quantity: 5000; Height: 0.45 mm; Length: 1.6 mm; Manufacturer: Panasonic; MEMORY: NO; Operating Temperature Range: - 55 C to + 155 C; Package / Case: 0603 (1608 metric); Packaging: Reel; Pricing 1: 5000=0.005, 5000=0.04 (EUR); Product: Thick Film Resistors SMD; Product Category: Thick Film Resistors; RoHS: True; Series: ERJ; Stock 1: 300000; Supplier 1: RSComponents; Supplier Part Number 1: 1229278; Termination Style: SMD/SMT; Type: Precision Thick Film Resistors SMD; Width: 0.8 mm
R2 R11 = R_Generic_0: RES,SMT 0603 0R 1/10WATT 5%; Manufacturer: Yageo; Manufacturer Part Number: RC0603JR-070RL; DESIG: Thick film Resistor; Power Rating: 100mW; Product Range: RC Series; Resistance: 0ohm; Resistor Case Style: 0603 [1608 Metric]; Resistor Element Type: Thick Film; SVHC: To Be Advised; Temperature Coefficient: ± 200ppm/°C; Voltage Rating: 50V; Automotive Qualification Standard: -; Component Kind: Standard; ComponentLink1Description: http://www.farnell.com/datasheets/2167052.pdf?src-supplier=Element14; ComponentLink1URL: http://www.farnell.com/datasheets/2167052.pdf?src-supplier=Element14; ComponentLink2Description: https://www.verical.com/server-webapp/html/getDatasheet?partID=329462&src-supplier=Verical; ComponentLink2URL: https://www.verical.com/server-webapp/html/getDatasheet?partID=329462&src-supplier=Verical; ComponentLink3Description: http://www.yageo.com/documents/recent/PYu-RC_Group_51_RoHS_L_9.pdf?src-supplier=Mouser; ComponentLink3URL: http://www.yageo.com/documents/recent/PYu-RC_Group_51_RoHS_L_9.pdf?src-supplier=Mouser; ComponentLink4Description: http://www.farnell.com/datasheets/443971.pdf?src-supplier=Element14; ComponentLink4URL: http://www.farnell.com/datasheets/443971.pdf?src-supplier=Element14; ComponentLink5Description: http://documents.rs-components.com/techlib://itc_doclist_GB.htm?stockno=6515918&src-supplier=RSComponents; ComponentLink5URL: http://documents.rs-components.com/techlib://itc_doclist_GB.htm?stockno=6515918&src-supplier=RSComponents; MSL: MSL 1 - Unlimited; Packaging: Cut Tape; Pricing 1: 5000=0.0023, 10000=0.002, 25000=0.0017, 50000=0.0016 (USD); Resistance Tolerance: ± 5%; Stock 1: 14198877; Supplier 1: Digi-Key; Supplier Part Number 1: 311-0.0GRTR-ND
R3 R5 R9 = R_Generic_0: RES,SMT 0603 10K 1/10WATT 5%; Manufacturer: Yageo; Manufacturer Part Number: RC0603JR-0710KL; DESIG: Thick film Resistor; Power (Watts): 0.1W, 1/10W; Resistance: 10 kOhms; Temperature Coefficient: ±100ppm/°C; Component Kind: Standard; ComponentLink1Description: http://www.yageo.com/documents/recent/PYu-RC_Group_51_RoHS_L_10.pdf?src-supplier=Digi-Key; ComponentLink1URL: http://www.yageo.com/documents/recent/PYu-RC_Group_51_RoHS_L_10.pdf?src-supplier=Digi-Key; Composition: Thick Film; Features: Moisture Resistant; Height - Seated (Max): 0.022" (0.55mm); Number of Terminations: 2; Operating Temperature: -55°C ~ 155°C; Package / Case: 0603 (1608 Metric); Part Status: Active; Pricing 1: 1=0.1, 10=0.017, 100=0.007, 1000=0.0032, 2500=0.0027 (USD); Size / Dimension: 0.063" L x 0.031" W (1.60mm x 0.80mm); Stock 1: 300615; Supplier 1: Digi-Key; Supplier Device Package: 603; Supplier Part Number 1: 311-10KGRCT-ND; Tolerance: ±5%
R4 = R_Generic_0: RES,SMT 0603 1K5 1/10WATT 5%; Manufacturer: Yageo; Manufacturer Part Number: RC0603FR-071K5L; DESIG: Thick film Resistor; Power Rating: 100 mW; Resistance: 1.5 kOhms; Temperature Coefficient: 100 PPM / C; Tolerance: 1 %; Voltage Rating: 50 V; Case Code - in: 0603; Case Code - mm: 1608; Component Kind: Standard; ComponentLink1Description: http://www.yageo.com/documents/recent/PYu-RC_Group_51_RoHS_L_10.pdf?src-supplier=Digi-Key; ComponentLink1URL: http://www.yageo.com/documents/recent/PYu-RC_Group_51_RoHS_L_10.pdf?src-supplier=Digi-Key; ComponentLink2Description: http://www.farnell.com/datasheets/2167052.pdf?src-supplier=Element14; ComponentLink2URL: http://www.farnell.com/datasheets/2167052.pdf?src-supplier=Element14; Operating Temperature Range: - 55 C to + 155 C; Packaging: Reel; Pricing 1: 1=0.1, 10=0.021, 100=0.0085, 1000=0.0038, 2500=0.0033 (USD); Product: Thick Film Resistors General Purpose; Series: RC; Stock 1: 2665573; Supplier 1: Digi-Key; Supplier Part Number 1: 311-1.50KHRCT-ND; Termination Style: SMD/SMT
R6 = R: RES,SMT 0603 10K 1/10WATT 5%; Manufacturer: Yageo; Manufacturer Part Number: RC0603JR-0710KL; COMPFIXED: NO; COMPGROUP: 0; COMPLOC: [1210.333,-1518.367]; COMPLOCKED: YES; COMPROT: 180; COMPSIDE: TOP; Power (Watts): 0.1W, 1/10W; Resistance: 10 kOhms; Temperature Coefficient: ±100ppm/°C; Unit Cost 1k: 0,00033; COMPHEIGHT: 0; COMPKEY: NO; Component Kind: Standard; ComponentLink1Description: http://www.yageo.com/documents/recent/PYu-RC_Group_51_RoHS_L_10.pdf?src-supplier=Digi-Key; ComponentLink1URL: http://www.yageo.com/documents/recent/PYu-RC_Group_51_RoHS_L_10.pdf?src-supplier=Digi-Key; Composition: Thick Film; DECOUPLER: NO; Features: Moisture Resistant; Height - Seated (Max): 0.022" (0.55mm); MEMORY: NO; Number of Terminations: 2; Operating Temperature: -55°C ~ 155°C; Package / Case: 0603 (1608 Metric); Part Status: Active; Pricing 1: 1=0.1, 10=0.017, 100=0.007, 1000=0.0032, 2500=0.0027 (USD); Size / Dimension: 0.063" L x 0.031" W (1.60mm x 0.80mm); Stock 1: 300615; Supplier 1: Digi-Key; Supplier Device Package: 603; Supplier Part Number 1: 311-10KGRCT-ND; Tolerance: ±5%
R7 R8 = R_Generic_0: RES,SMT 0603 120R 1/10WATT 5%; Manufacturer: Yageo; Manufacturer Part Number: RC0603FR-07120RL; DESIG: Thick film Resistor; Power Rating: 100 mW; Resistance: 120 Ohms; Temperature Coefficient: 100 PPM / C; Tolerance: 1 %; Voltage Rating: 50 V; Case Code - in: 0603; Case Code - mm: 1608; Component Kind: Standard; ComponentLink1Description: http://www.yageo.com/documents/recent/PYu-RC_Group_51_RoHS_L_10.pdf?src-supplier=Digi-Key; ComponentLink1URL: http://www.yageo.com/documents/recent/PYu-RC_Group_51_RoHS_L_10.pdf?src-supplier=Digi-Key; ComponentLink2Description: http://www.farnell.com/datasheets/2167052.pdf?src-supplier=Element14; ComponentLink2URL: http://www.farnell.com/datasheets/2167052.pdf?src-supplier=Element14; ComponentLink3Description: http://www.farnell.com/datasheets/1868787.pdf?src-supplier=Element14; ComponentLink3URL: http://www.farnell.com/datasheets/1868787.pdf?src-supplier=Element14; ComponentLink4Description: http://www.farnell.com/datasheets/443971.pdf?src-supplier=Element14; ComponentLink4URL: http://www.farnell.com/datasheets/443971.pdf?src-supplier=Element14; Operating Temperature Range: - 55 C to + 155 C; Packaging: Reel; Pricing 1: 1=0.1, 10=0.021, 100=0.0085, 1000=0.0038, 2500=0.0033 (USD); Product: Thick Film Resistors General Purpose; Series: RC; Stock 1: 155053; Supplier 1: Digi-Key; Supplier Part Number 1: 311-120HRCT-ND; Termination Style: SMD/SMT
R12 R13 = R_Generic_0: RES,SMT 0603 2K2 1/10WATT 5%; Manufacturer: Yageo; Manufacturer Part Number: RC0603FR-072K2L; DESIG: Thick film Resistor; Power Rating: 100 mW; Resistance: 2.2 kOhms; Temperature Coefficient: 100 PPM / C; Tolerance: 1 %; Voltage Rating: 50 V; Case Code - in: 0603; Case Code - mm: 1608; Component Kind: Standard; ComponentLink1Description: http://www.farnell.com/datasheets/1868787.pdf?src-supplier=Element14; ComponentLink1URL: http://www.farnell.com/datasheets/1868787.pdf?src-supplier=Element14; ComponentLink2Description: http://www.yageo.com/documents/recent/PYu-RC_Group_51_RoHS_L_10.pdf?src-supplier=Digi-Key; ComponentLink2URL: http://www.yageo.com/documents/recent/PYu-RC_Group_51_RoHS_L_10.pdf?src-supplier=Digi-Key; ComponentLink3Description: http://www.farnell.com/datasheets/2167052.pdf?src-supplier=Element14; ComponentLink3URL: http://www.farnell.com/datasheets/2167052.pdf?src-supplier=Element14; Operating Temperature Range: - 55 C to + 155 C; Packaging: Reel; Pricing 1: 1=0.1, 10=0.021, 100=0.0085, 1000=0.0038, 2500=0.0033 (USD); Product: Thick Film Resistors General Purpose; Series: RC; Stock 1: 5949227; Supplier 1: Digi-Key; Supplier Part Number 1: 311-2.20KHRCT-ND; Termination Style: SMD/SMT
SW2 = SW SPST/SM: CONN,TH HEADER 2PIN 2.54MM
U1 = LD3985: IC REG LINEAR 3.3V 300MA SOT23-5; Manufacturer: Texas Instruments; Dropout Voltage (Max): 375 mV; Input Voltage MAX: 5.5 V; Input Voltage MIN: 2 V; Line Regulation: 1 mV; Maximum Power Dissipation: 500 mW; Number of Outputs: 1; Output Type: Fixed; Output Voltage: 3 V; PSRR / Ripple Rejection (Typ): 68 dB; Voltage Regulation Accuracy: 2 %; Component Kind: Standard; ComponentLink1Description: http://www.farnell.com/datasheets/1882072.pdf?src-supplier=Element14; ComponentLink1URL: http://www.farnell.com/datasheets/1882072.pdf?src-supplier=Element14; ComponentLink2Description: http://www.ti.com/lit/ds/symlink/tlv702.pdf?src-supplier=Digi-Key; ComponentLink2URL: http://www.ti.com/lit/ds/symlink/tlv702.pdf?src-supplier=Digi-Key; Factory Pack Quantity: 3000; Load Regulation: 1 mV; Manufacturer Part Number: TLV70233DBVR; Maximum Operating Temperature: + 125 C; Minimum Operating Temperature: - 40 C; Mounting Style: SMD/SMT; Output Current: 300 mA; Package / Case: SOT-23-5; Packaging: Reel; Pricing 1: 1=0.41, 10=0.283, 100=0.133, 1000=0.102, 3000=0.084, 9000=0.077, 24000=0.072, 45000=0.064, 99000=0.062 (USD); Product Category: Low Dropout Regulators - LDO; RoHS: Details; Stock 1: 22787; Supplier 1: Mouser; Supplier Part Number 1: 595-TLV70233DBVR; Library Name: SSTE.SCHLIB
U2 = IP4234CZ6: TVS, DIODE 5.5V 6TSOP USB 2.0; Manufacturer: Nexperia; Component Kind: Standard; Manufacturer Part Number: IP4234CZ6,125; Pricing 1: 1=0.43, 10=0.369, 100=0.2759, 500=0.2167, 1000=0.1675 (USD); Stock 1: 150321; Supplier 1: Digi-Key; Supplier Part Number 1: 1727-4717-1-ND; Library Name: SSTE.SCHLIB
U3 = MAX485: IC, LOW POWER,HALF DUPLEX RS485 TRANSCEIVER; Manufacturer: TEXAS; Manufacturer Part Number: SN75176ADR; COMPHEIGHT: 0; Component Kind: Standard; Library Name: vdb.PcbLib
U4 = TPD2E007: TVS DIODE,SC70-3 2-Channel ESD Protection Array; Manufacturer: Texas Instruments; Power Line Protection: No; Voltage - Breakdown (Min): 14V; Voltage - Reverse Standoff (Typ): 13V (Max); Applications: General Purpose; Base Part Number: TPD2E007; Capacitance @ Frequency: -; Category: Circuit Protection; Component Kind: Standard; ComponentLink1Description: http://www.ti.com/lit/ds/symlink/tpd2e007.pdf; ComponentLink1URL: http://www.ti.com/lit/ds/symlink/tpd2e007.pdf; Current - Peak Pulse (10/1000µs): -; Manufacturer Part Number: TPD2E007DCKR; Mounting Type: Surface Mount; Operating Temperature: -40°C ~ 85°C (TA); Package / Case: SC-70, SOT-323; Packaging: Cut Tape (CT); Part Status: Active; Power - Peak Pulse: -; Pricing 1: 1=0.71, 10=0.628, 100=0.4816, 500=0.38068, 1000=0.30455 (USD); RoHS: RoHS Compliant; Stock 1: 24900; Supplier 1: Digi-Key; Supplier Device Package: SC-70-3; Supplier Part Number 1: 296-25281-1-ND; Type: Zener; Voltage - Clamping (Max) @ Ipp: -; Library Name: SSTE.SCHLIB
U5 = TPD2E007: TVS,Bi-Directional CAN Bus ESD Protection Diode - SOT-23; Manufacturer: Nexperia; Manufacturer Part Number: PESD2CAN,215; Component Kind: Standard; Pricing 1: 1=0.41, 10=0.293, 100=0.135, 1000=0.104, 3000=0.088, 9000=0.088 (USD); Stock 1: 46798; Supplier 1: Mouser; Supplier Part Number 1: 771-PESD2CAN-T/R; Library Name: SSTE.SCHLIB
U6 = TJF1051T/3: IC,TRANSCEIVER HALF 1/1 8SO; Manufacturer: NXP USA Inc.; Data Rate: 5Mbps; Protocol: CAN; Voltage - Supply: 4.5 V ~ 5.5 V; Category: Integrated Circuits (ICs); Component Kind: Standard; ComponentLink1Description: http://www.nxp.com/documents/data_sheet/TJF1051.pdf; ComponentLink1URL: http://www.nxp.com/documents/data_sheet/TJF1051.pdf; Duplex: Half; Manufacturer Part Number: TJF1051T/3,118; Mounting Type: Surface Mount; Number of Drivers/Receivers: 1/1; Operating Temperature: -40°C ~ 85°C; Package / Case: 8-SOIC (0.154", 3.90mm Width); Packaging: Cut Tape (CT); Part Status: Active; Pricing 1: 1=0.85, 10=0.761, 100=0.5934, 500=0.49024, 1000=0.38703 (USD); RoHS: RoHS Compliant; Stock 1: 14929; Supplier 1: Digi-Key; Supplier Device Package: 8-SO; Supplier Part Number 1: 568-11881-1-ND; Type: Transceiver; Library Name: SSTE.SCHLIB
U7 = STM32F105xx: IC,QFN64 32BIT ARM CORTEX M3 STM32 SERIES; Manufacturer: ST; Manufacturer Part Number: STM32F105R8; Component Kind: Standard
Y2 = 12MHz: XTAL,25.0000MHZ 20PF SMD; Manufacturer: ECS Inc.; Manufacturer Part Number: ECS-250-20-33-CKM-TR; Frequency Stability: ±10ppm; Operating Mode: Fundamental; Category: Crystals, Oscillators, Resonators; Component Kind: Standard; ComponentLink1Description: https://www.ecsxtal.com/store/pdf/ecx-32.pdf; ComponentLink1URL: https://www.ecsxtal.com/store/pdf/ecx-32.pdf; Frequency Tolerance: ±10ppm; Height - Seated (Max): 0.032" (0.80mm); Load Capacitance: 20pF; Mounting Type: Surface Mount; Operating Temperature: -20°C ~ 70°C; Package / Case: 4-SMD, No Lead; Packaging: Digi-Reel®; Part Status: Active; Pricing 1: 1=1.09, 10=0.961, 50=0.8988, 100=0.7942, 500=0.7524 (USD); Ratings: -; RoHS: RoHS Compliant; Size / Dimension: 0.126" L x 0.098" W (3.20mm x 2.50mm); Stock 1: 19496; Supplier 1: Digi-Key; Supplier Part Number 1: XC1817DKR-ND; Type: MHz Crystal
P1 = HEADER-1X7: Library Name: TH Connectors.SchLib; Supplier 1: Digi-Key; Supplier Part Number 1: S1011E-36-ND
